# Altium schematic sheet Photodiode and Thermocouple Amplifiers.SchDoc
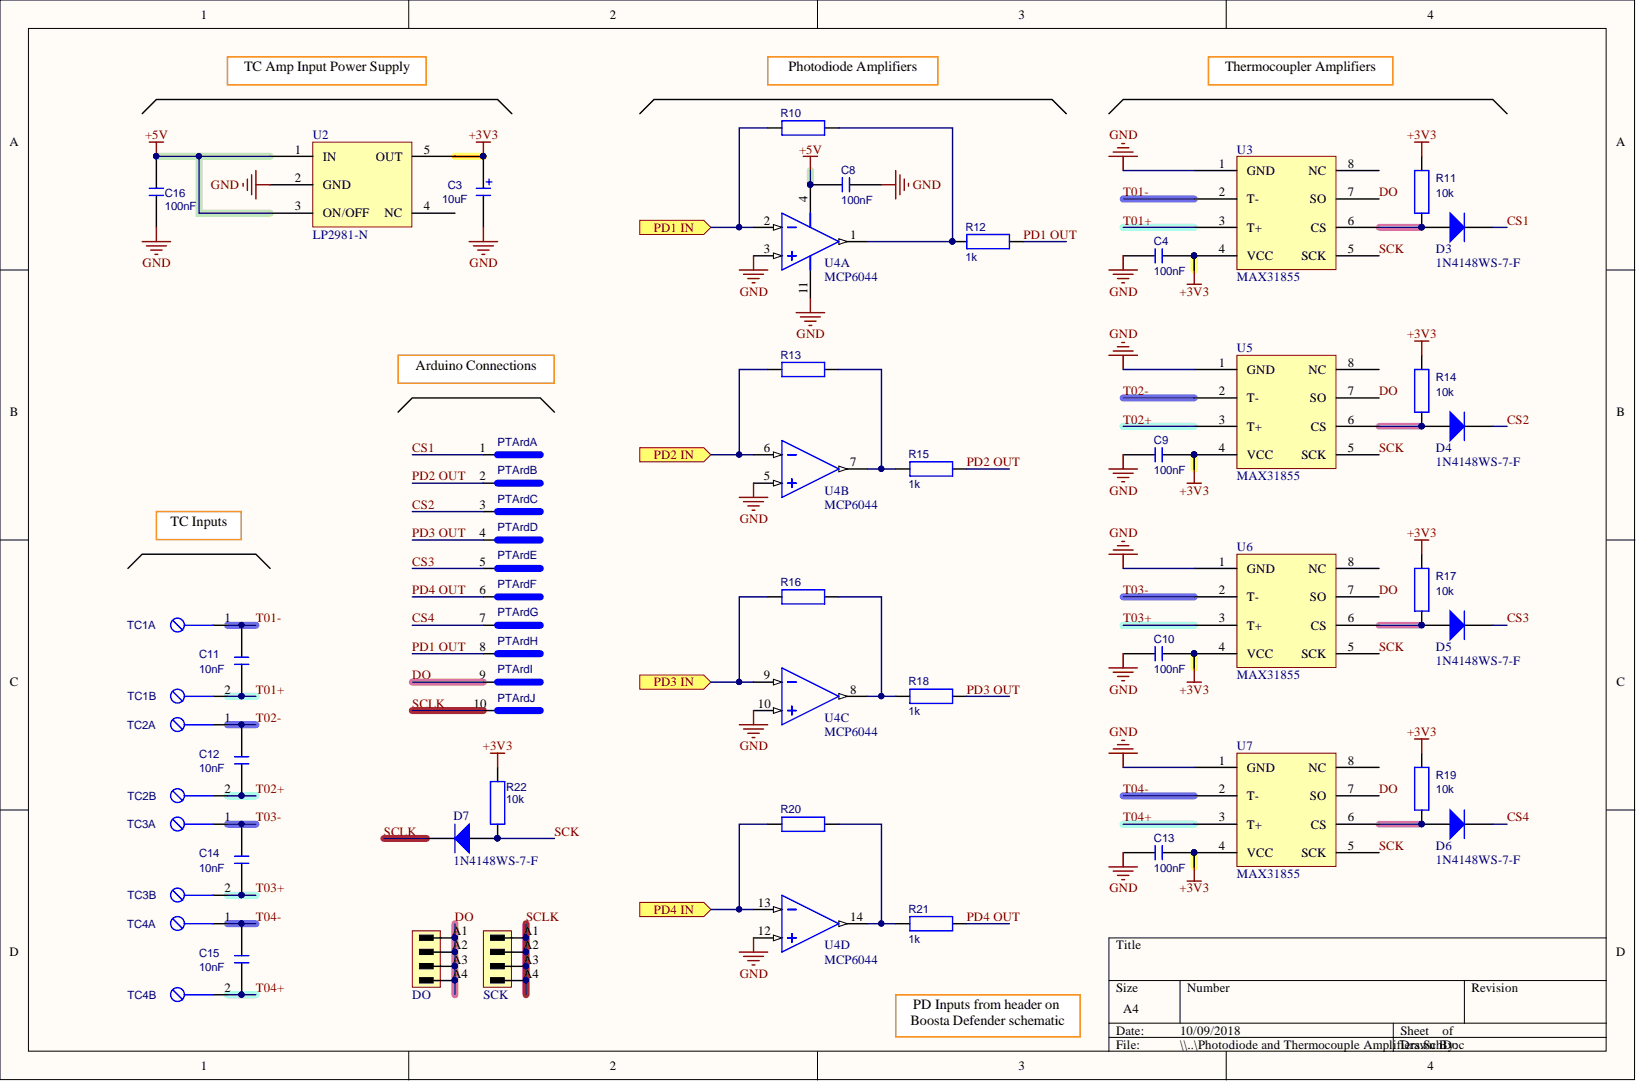
[diagram p0: Photodiode and Thermocouple Amplifiers.SchDoc]

## Parts
C3  value 10uF  [T491_B]  (hidden comment: T491)
C4  value 100nF  [CAPACITOR SMD 0805]  (hidden comment: Capacitor SMD 0805)
C8  value 100nF  [CAPACITOR SMD 0805]  (hidden comment: Capacitor SMD 0805)
C9  value 100nF  [CAPACITOR SMD 0805]  (hidden comment: Capacitor SMD 0805)
C10  value 100nF  [CAPACITOR SMD 0805]  (hidden comment: Capacitor SMD 0805)
C11  value 10nF  [CAPACITOR SMD 0805]  (hidden comment: Capacitor SMD 0805)
C12  value 10nF  [CAPACITOR SMD 0805]  (hidden comment: Capacitor SMD 0805)
C13  value 100nF  [CAPACITOR SMD 0805]  (hidden comment: Capacitor SMD 0805)
C14  value 10nF  [CAPACITOR SMD 0805]  (hidden comment: Capacitor SMD 0805)
C15  value 10nF  [CAPACITOR SMD 0805]  (hidden comment: Capacitor SMD 0805)
C16  value 100nF  [CAPACITOR SMD 0805]  (hidden comment: Capacitor SMD 0805)
D3  1N4148WS-7-F  [Diode SMA SOD323]
D4  1N4148WS-7-F  [Diode SMA SOD323]
D5  1N4148WS-7-F  [Diode SMA SOD323]
D6  1N4148WS-7-F  [Diode SMA SOD323]
D7  1N4148WS-7-F  [Diode SMA SOD323]
DO  Pins 4  [Pins 4]  (value not drawn)
PTArd  (no value)  [T821110A1S100CEU]  (parts A,B,C,D,E,F,G,H,I,J)
R10  Resistor Axial 0.25W  [Resistor, Axial 0.6mm Leads, Vertical]  (value not drawn)
R11  value 10k  [RESISTOR SMD 0805]  (hidden comment: Resistor SMD 0805)
R12  value 1k  [RESISTOR SMD 0805]  (hidden comment: Resistor SMD 0805)
R13  Resistor Axial 0.25W  [Resistor, Axial 0.6mm Leads, Vertical]  (value not drawn)
R14  value 10k  [RESISTOR SMD 0805]  (hidden comment: Resistor SMD 0805)
R15  value 1k  [RESISTOR SMD 0805]  (hidden comment: Resistor SMD 0805)
R16  Resistor Axial 0.25W  [Resistor, Axial 0.6mm Leads, Vertical]  (value not drawn)
R17  value 10k  [RESISTOR SMD 0805]  (hidden comment: Resistor SMD 0805)
R18  value 1k  [RESISTOR SMD 0805]  (hidden comment: Resistor SMD 0805)
R19  value 10k  [RESISTOR SMD 0805]  (hidden comment: Resistor SMD 0805)
R20  Resistor Axial 0.25W  [Resistor, Axial 0.6mm Leads, Vertical]  (value not drawn)
R21  value 1k  [RESISTOR SMD 0805]  (hidden comment: Resistor SMD 0805)
R22  value 10k  [RESISTOR SMD 0805]  (hidden comment: Resistor SMD 0805)
SCK  Pins 4  [Pins 4]  (value not drawn)
TC1  MKDSN 1,5/ 2-5,08  [MKDSN 1,5/ 2-5,08]  (value not drawn)  (parts A,B)
TC2  MKDSN 1,5/ 2-5,08  [MKDSN 1,5/ 2-5,08]  (value not drawn)  (parts A,B)
TC3  MKDSN 1,5/ 2-5,08  [MKDSN 1,5/ 2-5,08]  (value not drawn)  (parts A,B)
TC4  MKDSN 1,5/ 2-5,08  [MKDSN 1,5/ 2-5,08]  (value not drawn)  (parts A,B)
U2  LP2981-N  [SOT-23 5pin]
U3  MAX31855  [SOIC-OA8_N]
U4  MCP6044  [PDIP300-14]  (parts A,B,C,D)
U5  MAX31855  [SOIC-OA8_N]
U6  MAX31855  [SOIC-OA8_N]
U7  MAX31855  [SOIC-OA8_N]

## Ports
PD1 IN, PD2 IN, PD3 IN, PD4 IN

## Nets
+3V3: C3.1 C4.2 C9.2 C10.2 C13.2 R11.2 R14.2 R17.2 R19.2 R22.2 U2.5(OUT) U3.4(VCC) U5.4(VCC) U6.4(VCC) U7.4(VCC)
+5V: C8.2 C16.2 U2.1(IN) U2.3(ON/OFF) U4.4
GND: C3.2 C4.1 C8.1 C9.1 C10.1 C13.1 C16.1 U2.2(GND) U3.1(GND) U4.3 U4.5 U4.10 U4.11 U4.12 U5.1(GND) U6.1(GND) U7.1(GND)
CS1: D3.2 PTArd.1
CS2: D4.2 PTArd.3
CS3: D5.2 PTArd.5
CS4: D6.2 PTArd.7
DO: DO.A1 DO.A2 DO.A3 DO.A4 PTArd.9 U3.7(SO) U5.7(SO) U6.7(SO) U7.7(SO)
PD1 IN: R10.1 U4.2 ; port PD1 IN
PD1 OUT: PTArd.8 R12.2
PD2 IN: R13.1 U4.6 ; port PD2 IN
PD2 OUT: PTArd.2 R15.2
PD3 IN: R16.1 U4.9 ; port PD3 IN
PD3 OUT: PTArd.4 R18.2
PD4 IN: R20.1 U4.13 ; port PD4 IN
PD4 OUT: PTArd.6 R21.2
SCK: D7.1 R22.1 U3.5(SCK) U5.5(SCK) U6.5(SCK) U7.5(SCK)
SCLK: D7.2 PTArd.10 SCK.A1 SCK.A2 SCK.A3 SCK.A4
T01+: C11.1 TC1.2 U3.3(T+)
T01-: C11.2 TC1.1 U3.2(T-)
T02+: C12.1 TC2.2 U5.3(T+)
T02-: C12.2 TC2.1 U5.2(T-)
T03+: C14.1 TC3.2 U6.3(T+)
T03-: C14.2 TC3.1 U6.2(T-)
T04+: C15.1 TC4.2 U7.3(T+)
T04-: C15.2 TC4.1 U7.2(T-)
NetD3_1: D3.1 R11.1 U3.6(CS)
NetD4_1: D4.1 R14.1 U5.6(CS)
NetD5_1: D5.1 R17.1 U6.6(CS)
NetD6_1: D6.1 R19.1 U7.6(CS)
NetR10_2: R10.2 R12.1 U4.1
NetR13_2: R13.2 R15.1 U4.7
NetR16_2: R16.2 R18.1 U4.8
NetR20_2: R20.2 R21.1 U4.14

Not connected: U2 4(NC); U3 8(NC); U5 8(NC); U6 8(NC); U7 8(NC)

## Library data of the parts
C3 = T491: Value: 10uF; Component Kind: Standard; Description: T491; Library Name: Kemet Passive.IntLib
C4 C8-C16 = Capacitor SMD 0805: Ceramic chip capacitor 0805; Component Kind: Standard; Library Name: TB_Capacitors.IntLib
D3-D7 = 1N4148WS-7-F: Small Signal Diode, Single, 75 V, 150 mA, 1.25 V, 4 ns, 2 A; Component Kind: Standard; Library Name: TB_Misc.IntLib
DO SCK = Pins 4: OMNIMATE Signal - series BL/SL 5.08; Construction_type: Male header; Contact_material: Cu-Leg; Contact_surface: tinned; DXF: SL_5.08HC_04_180B_3.2SN_OR_BX_1147360000.DXF; ID1: SL_5_08HC_180B_04__3_2_OR_BX; Insulating_material: PA GF; Mounting_onto_the_PCB: Solder connection; No_of_poles: 4; Outgoing_elbow: 180°; PADS_Symbol: Header_Male_04_1x04; Pitch_inch: 0,2 inch; Pitch_mm: 5,08 mm; Rated_current_use_group_B_UL_1059: 16 A; Rated_voltage_for_surge_voltage_class_pollution_degree_II_2: 400 V; Rated_voltage_use_group_B_UL_1059: 300 V; Short_text_for_material: SL 5.08HC/04/180B 3.2SN OR BX; Side_termination_characteristic: Dovetails for fixing blocks; Solder_pin_length_mm: 3,2 mm; UL_94_flammability_rating: V-0; Weidmueller_Order_No: 1147360000; Colour: Orange; Component Kind: Standard; ComponentLink1Description: Weidmueller Product Catalogue -> SL 5.08HC/04/180B; ComponentLink1Url: http://catalog.weidmueller.com/catalog/Start.do?localeId=en&ObjectID=1147360000; Library Name: TB_Connectors.SchLib; Packaging: Box; Qty: 100 PCS; ReleaseDate: 15.08.2014; Revision: V1
PTArd = T821110A1S100CEU: AMPHENOL T821110A1S100CEU Wire-To-Board Connector, Vertical, 2.54 mm, 10 Contacts, Header, T821 Series, Through Hole, 2 Rows; Component Kind: Standard; Library Name: TB_Connectors.SchLib
R10 R13 R16 R20 = Resistor Axial 0.25W: Resistor, Through-Hole, 0.6mm Axial Leaded, 0.25W; Component Kind: Standard; Library Name: TB_Resistors.IntLib
R11 R12 R14 R15 R17-R19 R21 R22 = Resistor SMD 0805: Resistor, SMD, 0805; Component Kind: Standard; Library Name: TB_Resistors.IntLib
U2 = LP2981-N: TEXAS INSTRUMENTS LP2981-N 100mA  ltralow Dropout Regulator; Component Kind: Standard; Library Name: TB_ICs.IntLib
U3 U5-U7 = MAX31855: MAXIM MAX31855 Digital Thermocouple IC; Component Kind: Standard; Library Name: TB_ICs.IntLib
U4 = MCP6044: Operational Amplifier, Quad, 4 Amplifier, 2.8 MHz, 0.003 V/µs, 1.4V to 5.5V, SOIC, 14 Pins; Component Kind: Standard; Library Name: TB_ICs.IntLib
TC1-TC4 = MKDSN 1,5/ 2-5,08: Component Kind: Standard; Description: MKDSN 1,5/ 2-5,08; Library Name: TB_Connectors.IntLib
